# Altium schematic sheet SD_I2C.SchDoc
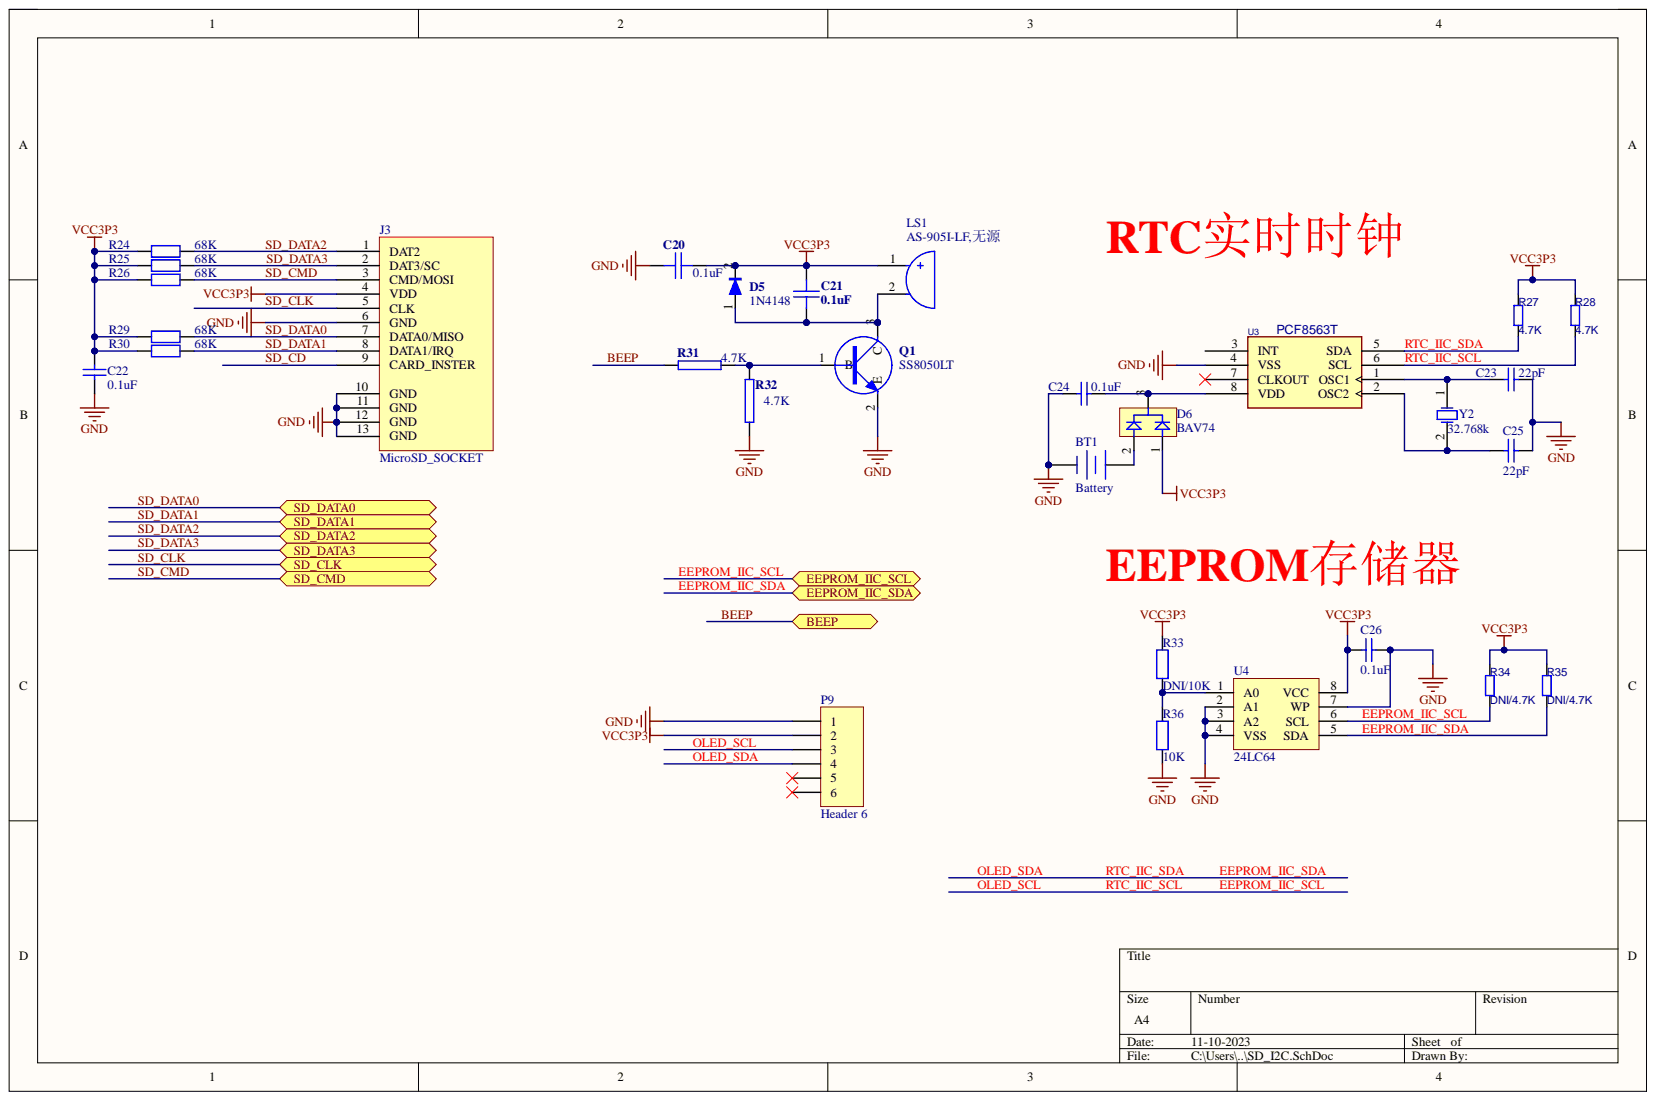
[diagram p0: SD_I2C.SchDoc]

## Parts
BT1  Battery  [CR1220]
C20  0.1uF  [C0402]
C21  0.1uF  [C0402]
C22  0.1uF  [C0402]
C23  22pF  [C0402]
C24  0.1uF  [C0402]
C25  22pF  [C0402]
C26  0.1uF  [C0402]
D5  1N4148  [SOD323]
D6  BAV74  [SOT23]
J3  MicroSD_SOCKET  [MicroSD_SOCKET]
LS1  BUZZER2  [BUZZER_9_5mm]  (value not drawn)
P9  Header 6  [LCD4-0r96-27r3x27r8MM]
Q1  value SS8050LT  [SOT23]  (hidden comment: NPN-1)
R24  68K  [C0402]
R25  68K  [C0402]
R26  68K  [C0402]
R27  4.7K  [R0402]
R28  4.7K  [R0402]
R29  68K  [C0402]
R30  68K  [C0402]
R31  4.7K  [R0402]
R32  4.7K  [R0402]
R33  DNI/10K  [R0402]
R34  DNI/4.7K  [R0402]
R35  DNI/4.7K  [R0402]
R36  10K  [R0402]
U3  PCF8563T  [SOP-8-1]
U4  24LC64  [SOP-8-1]
Y2  32.768k  [MC-146]

## Ports
BEEP, EEPROM_IIC_SCL, EEPROM_IIC_SDA, SD_CLK, SD_CMD, SD_DATA0, SD_DATA1, SD_DATA2, SD_DATA3

## Nets
GND: BT1.2 C20.1 C22.2 C23.2 C24.1 C25.2 C26.1 J3.6(GND) J3.10(GND) J3.11(GND) J3.12(GND) J3.13(GND) P9.1 Q1.2 R32.2 R36.1 U3.4(VSS) U4.2(A1) U4.3(A2) U4.4(VSS) U4.7(WP)
VCC3P3: C20.2 C21.2 C22.1 C26.2 D5.2 D6.1 J3.4(VDD) LS1.1 P9.2 R24.1 R25.1 R26.1 R27.1 R28.1 R29.1 R30.1 R33.2 R34.1 R35.1 U4.8(VCC)
BEEP: R31.2 ; port BEEP
EEPROM_IIC_SCL: P9.3 R28.2 R34.2 U3.6(SCL) U4.6(SCL) ; port EEPROM_IIC_SCL
EEPROM_IIC_SDA: P9.4 R27.2 R35.2 U3.5(SDA) U4.5(SDA) ; port EEPROM_IIC_SDA
SD_CD: J3.9(CARD_INSTER)
SD_CLK: J3.5(CLK) ; port SD_CLK
SD_CMD: J3.3(CMD/MOSI) R26.2 ; port SD_CMD
SD_DATA0: J3.7(DATA0/MISO) R29.2 ; port SD_DATA0
SD_DATA1: J3.8(DATA1/IRQ) R30.2 ; port SD_DATA1
SD_DATA2: J3.1(DAT2) R24.2 ; port SD_DATA2
SD_DATA3: J3.2(DAT3/SC) R25.2 ; port SD_DATA3
NetBT1_1: BT1.1 D6.2
NetC21_1: C21.1 D5.1 LS1.2 Q1.3
NetC23_1: C23.1 U3.1(OSC1) Y2.1
NetC24_2: C24.2 D6.3 U3.8(VDD)
NetC25_1: C25.1 U3.2(OSC2) Y2.2
NetQ1_1: Q1.1 R31.1 R32.1
NetR33_1: R33.1 R36.2 U4.1(A0)

Not connected: P9 5 6; U3 3(INT) 7(CLKOUT)

## Library data of the parts
BT1 = Battery: Multicell Battery; Component Kind: Standard; LatestRevisionDate: 17-Jul-2002; LatestRevisionNote: Re-released for DXP Platform.; Library Name: Miscellaneous Devices.IntLib; PackageDescription: Battery; 2 Leads; PackageReference: BAT-2; Published: 24-Mar-1999; Publisher: Altium Limited
C20 C21 = 1.02.17.0006: 0.1μF,±10%,16V,X7R,0603,RoHS; Database Table Name: VErpDataInfo; 名称: 电容; 封装: 0603; 设备封装名称: C0603_0.1uF_X7R_16V_10%; 贴片和直插: SMT; Component Kind: Standard; ComponentLink1Description: ErpDataInfo; ComponentLink1URL: http://erp.zlgmcu.com/ErpExt/ErpData/ListMateriel.aspx?pkey=1.02.17.0006; Library Name: ErpMaterialData.DbLib; RoHS: RoHS; Value: 0.1uF; 型号: 0.1μF,±10%,16V,X7R; 物料代码: 1.02.17.0006
C22 C24 C26 = Cap: Capacitor; Component Kind: Standard; LatestRevisionDate: 17-Jul-2002; LatestRevisionNote: Re-released for DXP Platform.; PackageReference: RAD-0.3; Published: 8-Jun-2000; Value: 0.1uF; Publisher: Altium Limited
  C24 C26: Library Name: Miscellaneous Devices.IntLib; PackageDescription: Radial Cap, Thru-Hole; 2 Leads; 0.3 in Pin Spacing; Signal Integrity: Cap; Simulation: CAP
  C22: Library Name: 元件库封装.pcblib
C23 C25 = CAP: Component Kind: Standard; Library Name: MySchlib.SchLib; Value: 22pF
D5 = 1.04.07.0027: 1N4148,SOD-323,RoHS; Database Table Name: VErpDataInfo; 名称: 二极管; 设备封装名称: SOD-323_1N4148; 贴片和直插: SMT; Component Kind: Standard; ComponentLink1Description: ErpDataInfo; ComponentLink1URL: http://erp.zlgmcu.com/ErpExt/ErpData/ListMateriel.aspx?pkey=1.04.07.0027; Library Name: ErpMaterialData.DbLib; RoHS: RoHS; Value: 1N4148; 型号: 1N4148; 封装: SOD-323; 物料代码: 1.04.07.0027
D6 = 1.04.07.0013: BAV74,SOT-23,,RoHS; Database Table Name: VErpDataInfo; 名称: 双二极管; 设备封装名称: SOT-23_BAV74; 贴片和直插: SMT; Component Kind: Standard; ComponentLink1Description: ErpDataInfo; ComponentLink1URL: http://erp.zlgmcu.com/ErpExt/ErpData/ListMateriel.aspx?pkey=1.04.07.0013; Library Name: ErpMaterialData.DbLib; RoHS: RoHS; Value: BAV74; 型号: BAV74; 封装: SOT-23; 物料代码: 1.04.07.0013
J3 = SDCARD_TF: Component Kind: Standard; Library Name: Schlib1.SchLib
LS1 = 1.06.09.0016: AS-905I-LF,无源,Ф9×4.8mm,常州立翔,RoHS; Database Table Name: VErpDataInfo; 厂商: 常州笠翔; 名称: 讯响器; 器件优选方案: 量产物料,优先选用; 贴片和直插: THT; Component Kind: Standard; ComponentLink1Description: ErpDataInfo; ComponentLink1URL: http://erp.zlgmcu.com/ErpExt/ErpData/ListMateriel.aspx?pkey=1.06.09.0016; Library Name: ErpMaterialData.DbLib; RoHS: RoHS; Value: AS-905I-LF,无源; 型号: AS-905I-LF,无源,Ф9×4.8mm; 物料代码: 1.06.09.0016
P9 = Header 6: Header, 6-Pin; Component Kind: Standard; LatestRevisionDate: 17-Jul-2002; LatestRevisionNote: Re-released for DXP Platform.; Library Name: Miscellaneous Connectors.IntLib; Signal Integrity: Connector; Publisher: Altium Limited
Q1 = 1.04.09.0001: SS8050LT(1.5A),SOT-23,江苏长电,RoHS; Database Table Name: VErpDataInfo; 名称: 三极管; 设备封装名称: SOT-23_SS8050LT; 贴片和直插: SMT; Component Kind: Standard; ComponentLink1Description: ErpDataInfo; ComponentLink1URL: http://erp.zlgmcu.com/ErpExt/ErpData/ListMateriel.aspx?pkey=1.04.09.0001; Library Name: ErpMaterialData.DbLib; RoHS: RoHS; 厂商: 江苏长电; 型号: SS8050LT(1.5A); 封装: SOT-23; 物料代码: 1.04.09.0001
R24-R26 R29 R30 R33 R36 = Res2: Resistor; Component Kind: Standard; LatestRevisionDate: 17-Jul-2002; LatestRevisionNote: Re-released for DXP Platform.; PackageReference: AXIAL-0.4; Published: 8-Jun-2000; Library Name: 元件封装库.pcblib; Publisher: Altium Limited
  R24-R26 R29 R30: Value: 68K
  R33: Value: DNI/10K
  R36: Value: 10K
R27 R28 = R: Value: DNI/4.7K; Component Kind: Standard; Library Name: 无极性.SchLib
R31 R32 = 1.01.17.0019: 4.7K,±5%,0603,RALEC,RoHS; Database Table Name: VErpDataInfo; Value: 4K7; 名称: 电阻; 封装: 0603; 设备封装名称: R0603_4.7K; 贴片和直插: SMT; Component Kind: Standard; ComponentLink1Description: ErpDataInfo; ComponentLink1URL: http://erp.zlgmcu.com/ErpExt/ErpData/ListMateriel.aspx?pkey=1.01.17.0019; Library Name: ErpMaterialData.DbLib; RoHS: RoHS; 厂商: RALEC; 型号: 4.7K,±5%; 物料代码: 1.01.17.0019
R34 R35 = R: Component Kind: Standard; Value: DNI/4.7K; Library Name: 无极性.SchLib
U3 = PCF8563: ICA_MFR_NAME: MAXIM INTEGRATED PRODUCTS INC; ICA_PARTNUM: $$2098133$$DS1302S; Part Number: TMP-13; Component Kind: Standard; Library Name: AC620V1.0.SCHLIB
U4 = 24LC256: Component Kind: Standard; Library Name: USB302.Lib
Y2 = XATL: Component Kind: Standard; Value: 32.768k; Library Name: MCA.SCHLIB
